# Altium schematic sheet PMIC.SchDoc
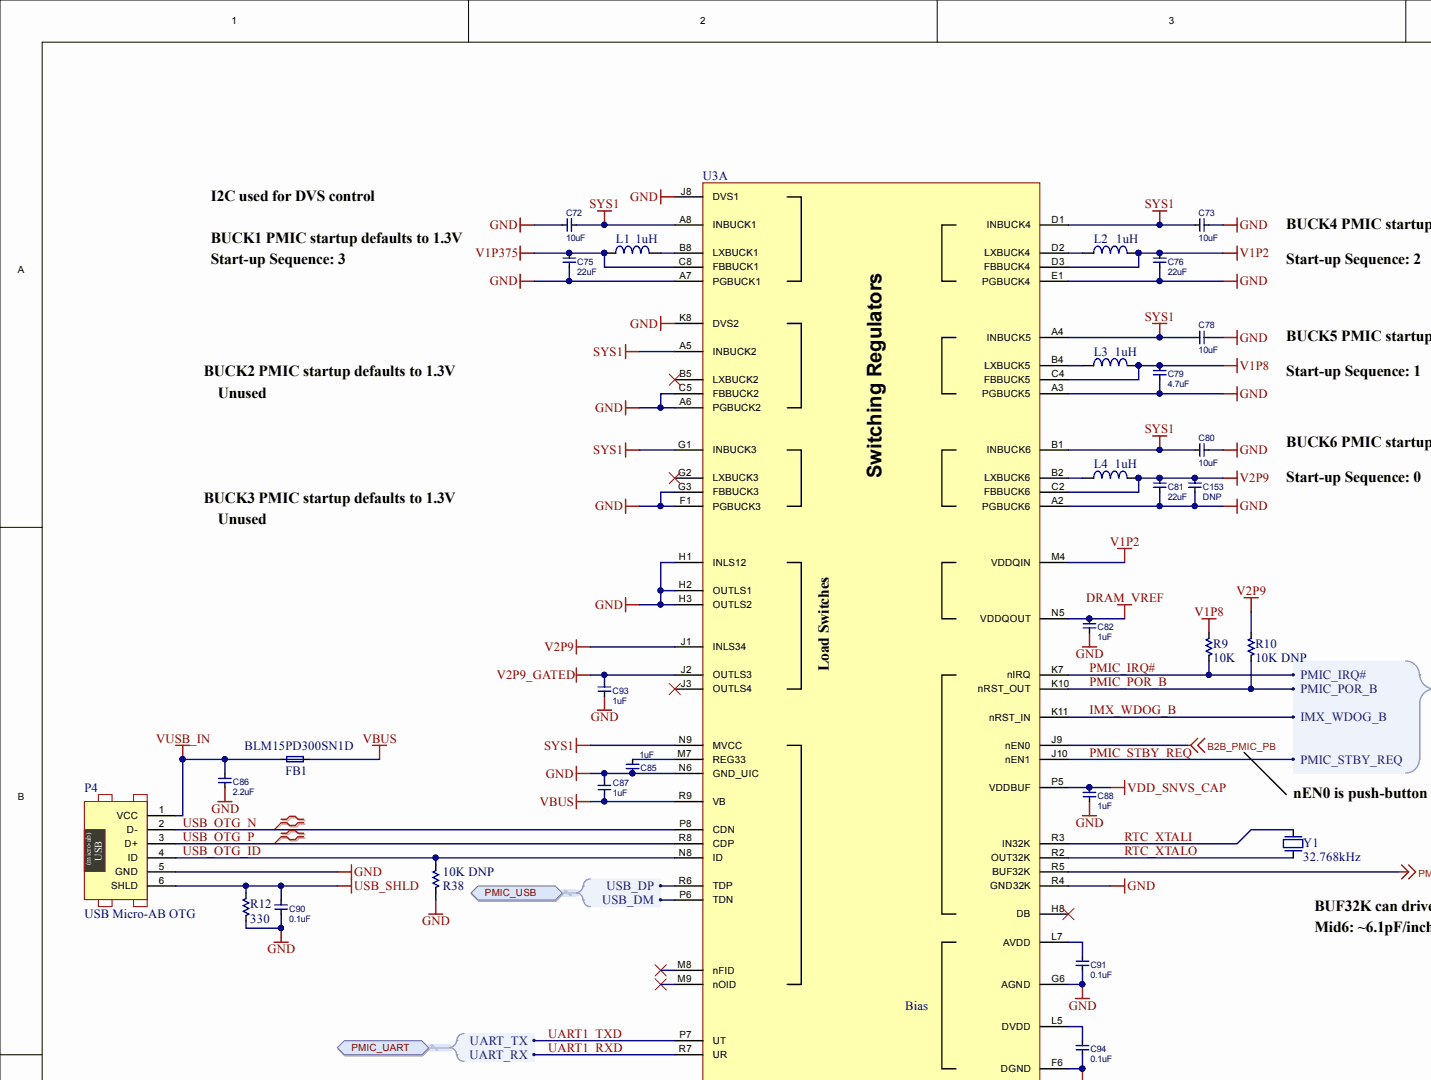
[diagram p0: PMIC.SchDoc, top left]
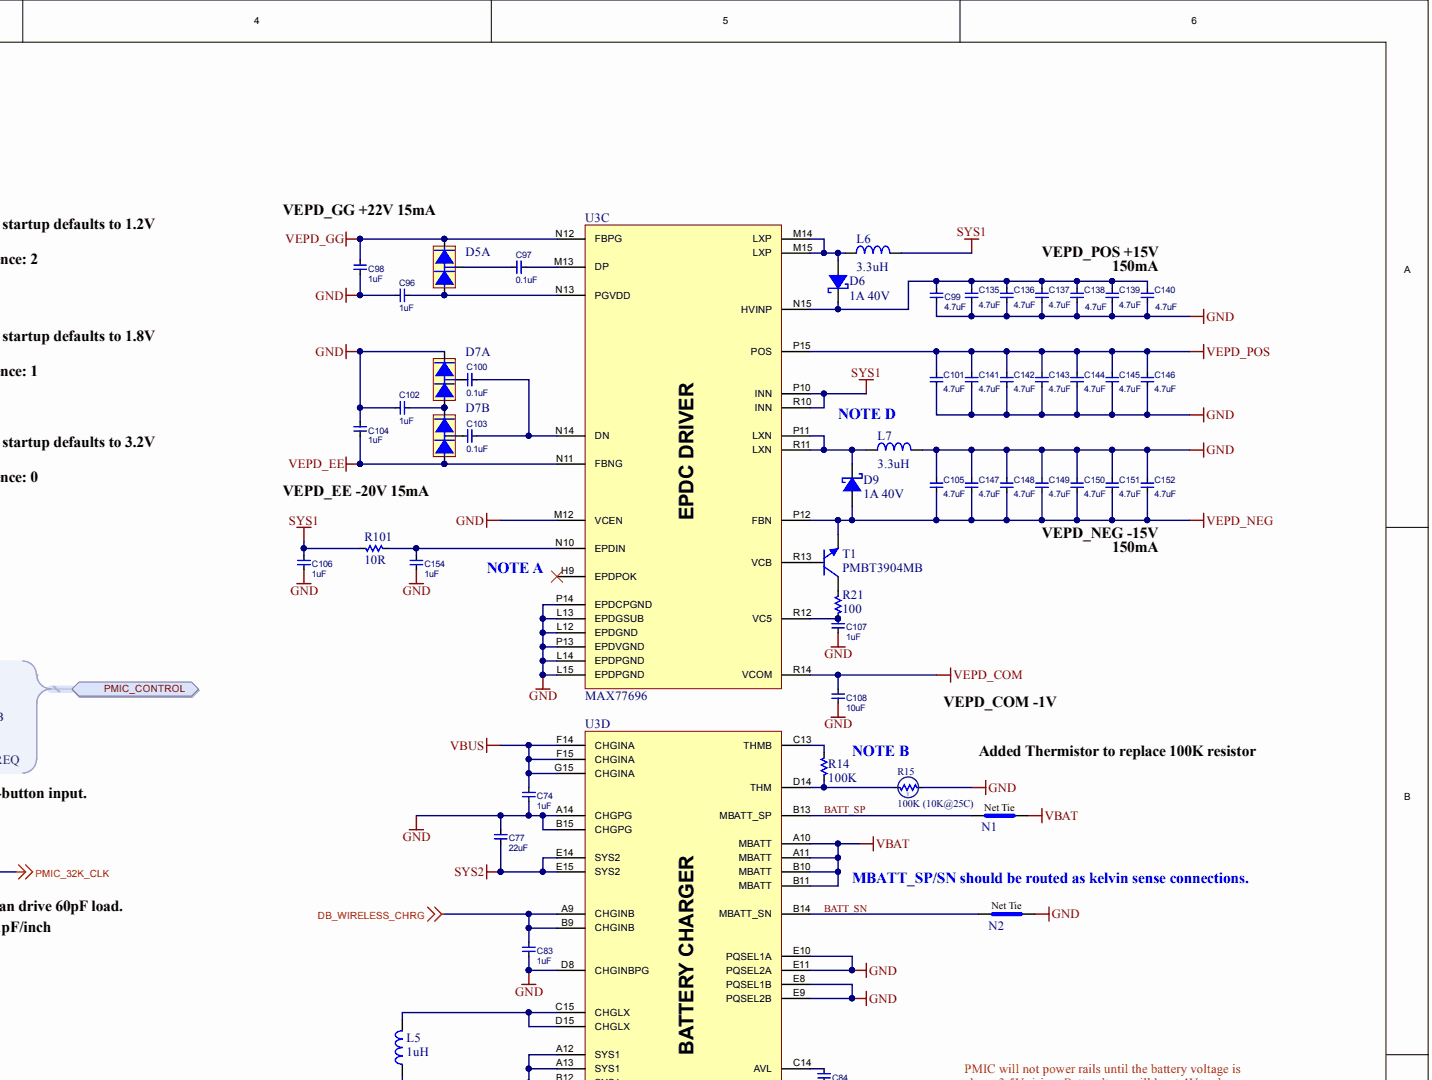
[diagram p1: PMIC.SchDoc, top right]
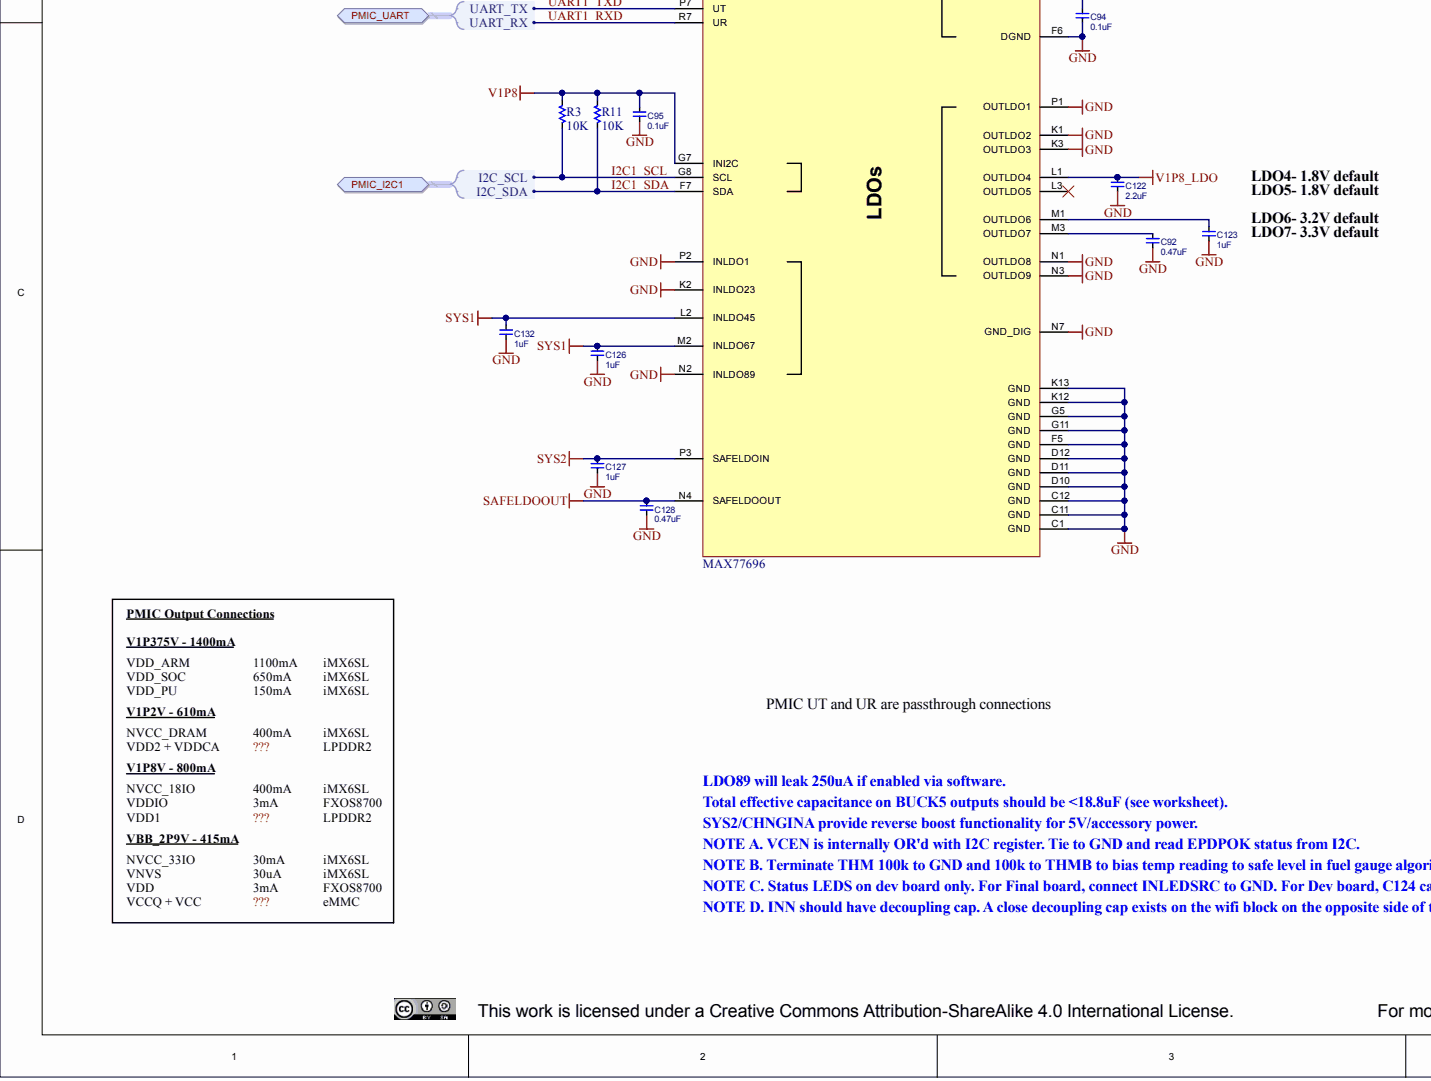
[diagram p2: PMIC.SchDoc, bottom left]
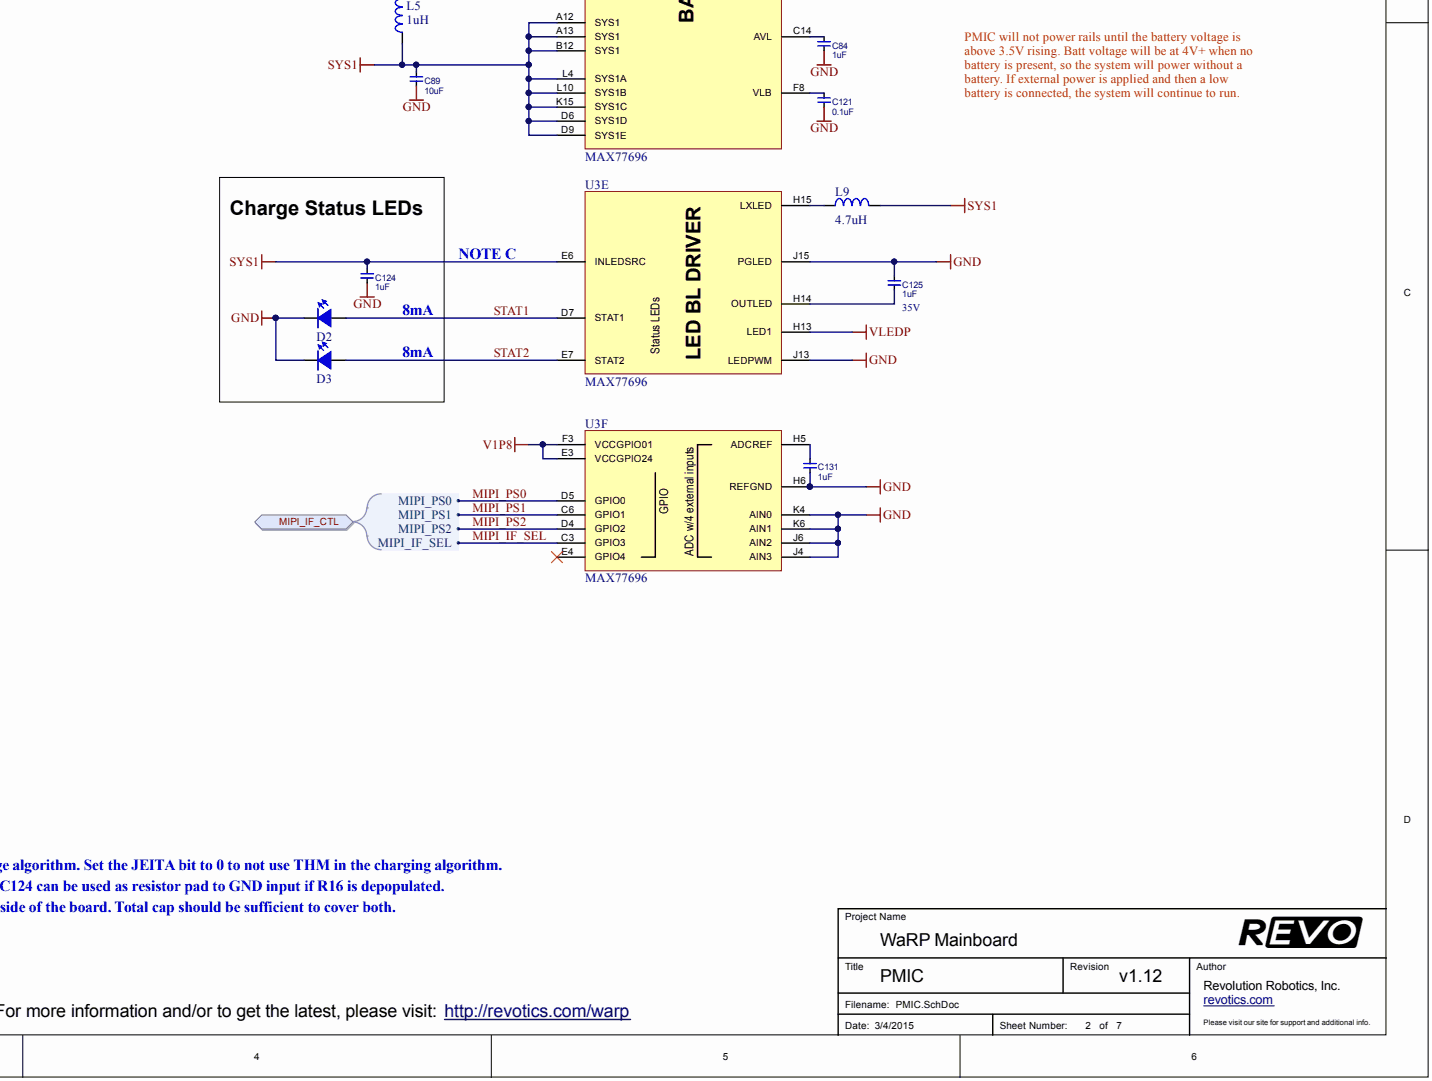
[diagram p3: PMIC.SchDoc, bottom right]

## Parts
C72  value 10uF  [0402 (1005) Cap High Density]  (hidden comment: CapacitorSM)
C73  value 10uF  [0402 (1005) Cap High Density]  (hidden comment: CapacitorSM)
C74  value 1uF  [0201 (0603) Cap High Density]  (hidden comment: CapacitorSM)
C75  value 22uF  [0603 (1608) Cap High Density]  (hidden comment: CapacitorSM)
C76  value 22uF  [0603 (1608) Cap High Density]  (hidden comment: CapacitorSM)
C77  value 22uF  [0603 (1608) Cap High Density]  (hidden comment: CapacitorSM)
C78  value 10uF  [0402 (1005) Cap High Density]  (hidden comment: CapacitorSM)
C79  value 4.7uF  [0402 (1005) Cap High Density]  (hidden comment: CapacitorSM)
C80  value 10uF  [0402 (1005) Cap High Density]  (hidden comment: CapacitorSM)
C81  value 22uF  [0603 (1608) Cap High Density]  (hidden comment: CapacitorSM)
C82  value 1uF  [0201 (0603) Cap High Density]  (hidden comment: CapacitorSM)
C83  value 1uF  [0402 (1005) Cap High Density]  (hidden comment: CapacitorSM)
C84  value 1uF  [0201 (0603) Cap High Density]  (hidden comment: CapacitorSM)
C85  value 1uF  [0201 (0603) Cap High Density]  (hidden comment: CapacitorSM)
C86  value 2.2uF  [0402 (1005) Cap High Density]  (hidden comment: CapacitorSM)
C87  value 1uF  [0201 (0603) Cap High Density]  (hidden comment: CapacitorSM)
C88  value 1uF  [0201 (0603) Cap High Density]  (hidden comment: CapacitorSM)
C89  value 10uF  [0402 (1005) Cap High Density]  (hidden comment: CapacitorSM)
C90  value 0.1uF  [0402 (1005) Cap High Density]  (hidden comment: CapacitorSM)
C91  value 0.1uF  [0201 (0603) Cap High Density]  (hidden comment: CapacitorSM)
C92  value 0.47uF  [0201 (0603) Cap High Density]  (hidden comment: CapacitorSM)
C93  value 1uF  [0201 (0603) Cap High Density]  (hidden comment: CapacitorSM)
C94  value 0.1uF  [0201 (0603) Cap High Density]  (hidden comment: CapacitorSM)
C95  value 0.1uF  [0201 (0603) Cap High Density]  (hidden comment: CapacitorSM)
C96  value 1uF  [0402 (1005) Cap High Density]  (hidden comment: CapacitorSM)
C97  value 0.1uF  [0402 (1005) Cap High Density]  (hidden comment: CapacitorSM)
C98  value 1uF  [0402 (1005) Cap High Density]  (hidden comment: CapacitorSM)
C99  value 4.7uF  [0603 (1608) Cap High Density]  (hidden comment: CapacitorSM)
C100  value 0.1uF  [0402 (1005) Cap High Density]  (hidden comment: CapacitorSM)
C101  value 4.7uF  [0603 (1608) Cap High Density]  (hidden comment: CapacitorSM)
C102  value 1uF  [0402 (1005) Cap High Density]  (hidden comment: CapacitorSM)
C103  value 0.1uF  [0402 (1005) Cap High Density]  (hidden comment: CapacitorSM)
C104  value 1uF  [0402 (1005) Cap High Density]  (hidden comment: CapacitorSM)
C105  value 4.7uF  [0603 (1608) Cap High Density]  (hidden comment: CapacitorSM)
C106  value 1uF  [0201 (0603) Cap High Density]  (hidden comment: CapacitorSM)
C107  value 1uF  [0201 (0603) Cap High Density]  (hidden comment: CapacitorSM)
C108  value 10uF  [0402 (1005) Cap High Density]  (hidden comment: CapacitorSM)
C121  value 0.1uF  [0201 (0603) Cap High Density]  (hidden comment: CapacitorSM)
C122  value 2.2uF  [0402 (1005) Cap High Density]  (hidden comment: CapacitorSM)
C123  value 1uF  [0201 (0603) Cap High Density]  (hidden comment: CapacitorSM)
C124  value 1uF  [0201 (0603) Cap High Density]  (hidden comment: CapacitorSM)
C125  value 1uF  Voltage: 35V  [0402 (1005) Cap High Density]  (hidden comment: CapacitorSM)
C126  value 1uF  [0201 (0603) Cap High Density]  (hidden comment: CapacitorSM)
C127  value 1uF  [0201 (0603) Cap High Density]  (hidden comment: CapacitorSM)
C128  value 0.47uF  [0201 (0603) Cap High Density]  (hidden comment: CapacitorSM)
C131  value 1uF  [0201 (0603) Cap High Density]  (hidden comment: CapacitorSM)
C132  value 1uF  [0201 (0603) Cap High Density]  (hidden comment: CapacitorSM)
C135  value 4.7uF  [0603 (1608) Cap High Density]  (hidden comment: CapacitorSM)
C136  value 4.7uF  [0603 (1608) Cap High Density]  (hidden comment: CapacitorSM)
C137  value 4.7uF  [0603 (1608) Cap High Density]  (hidden comment: CapacitorSM)
C138  value 4.7uF  [0603 (1608) Cap High Density]  (hidden comment: CapacitorSM)
C139  value 4.7uF  [0603 (1608) Cap High Density]  (hidden comment: CapacitorSM)
C140  value 4.7uF  [0603 (1608) Cap High Density]  (hidden comment: CapacitorSM)
C141  value 4.7uF  [0603 (1608) Cap High Density]  (hidden comment: CapacitorSM)
C142  value 4.7uF  [0603 (1608) Cap High Density]  (hidden comment: CapacitorSM)
C143  value 4.7uF  [0603 (1608) Cap High Density]  (hidden comment: CapacitorSM)
C144  value 4.7uF  [0603 (1608) Cap High Density]  (hidden comment: CapacitorSM)
C145  value 4.7uF  [0603 (1608) Cap High Density]  (hidden comment: CapacitorSM)
C146  value 4.7uF  [0603 (1608) Cap High Density]  (hidden comment: CapacitorSM)
C147  value 4.7uF  [0603 (1608) Cap High Density]  (hidden comment: CapacitorSM)
C148  value 4.7uF  [0603 (1608) Cap High Density]  (hidden comment: CapacitorSM)
C149  value 4.7uF  [0603 (1608) Cap High Density]  (hidden comment: CapacitorSM)
C150  value 4.7uF  [0603 (1608) Cap High Density]  (hidden comment: CapacitorSM)
C151  value 4.7uF  [0603 (1608) Cap High Density]  (hidden comment: CapacitorSM)
C152  value 4.7uF  [0603 (1608) Cap High Density]  (hidden comment: CapacitorSM)
C153  value DNP  [0402 (1005) Cap High Density DNP]  (hidden comment: CapacitorSM)
C154  value 1uF  [0201 (0603) Cap High Density]  (hidden comment: CapacitorSM)
D2  LED  [LED 0603 (1608) High Density]  (value not drawn)
D3  LED  [LED 0603 (1608) High Density]  (value not drawn)
D5  BAV99BRV  [SOT-563 - NoMetalMarker]  (value not drawn)  (part A)
D6  value 1A 40V  [0502 Diode]  (hidden comment: Schottky Diode)
D7  BAV99BRV  [SOT-563 - NoMetalMarker]  (value not drawn)  (parts A,B)
D9  value 1A 40V  [0502 Diode]  (hidden comment: Schottky Diode)
FB1  Part No.: BLM15PD300SN1D  [0402 (1005) Cap High Density]  (hidden comment: Ferrite Bead)
L1  value 1uH  [0806 (2016) IND HIGH DENSITY]  (hidden comment: Inductor)
L2  value 1uH  [0806 (2016) IND HIGH DENSITY]  (hidden comment: Inductor)
L3  value 1uH  [0806 (2016) IND HIGH DENSITY]  (hidden comment: Inductor)
L4  value 1uH  [0806 (2016) IND HIGH DENSITY]  (hidden comment: Inductor)
L5  value 1uH  [0806 (2016) IND HIGH DENSITY]  (hidden comment: Inductor)
L6  value 3.3uH  [0808 (2020) IND HIGH DENSITY]  (hidden comment: Inductor)
L7  value 3.3uH  [0808 (2020) IND HIGH DENSITY]  (hidden comment: Inductor)
L9  value 4.7uH  [0808 (2020) IND HIGH DENSITY]  (hidden comment: Inductor)
N1  Net Tie  [NetTieSmall]
N2  Net Tie  [NetTieSmall]
P4  USB Micro-AB OTG  [USB MICRO-AB Mid Board]
R3  value 10K  [0201 (0603) Res High Density]  (hidden comment: Resistor)
R9  value 10K  [0201 (0603) Res High Density]  (hidden comment: Resistor)
R10  value 10K DNP  [0201 (0603) Res High Density DNP]  (hidden comment: Resistor)
R11  value 10K  [0201 (0603) Res High Density]  (hidden comment: Resistor)
R12  value 330  [0402 (1005) Res High Density]  (hidden comment: Resistor)
R14  value 100K  [0201 (0603) Res High Density]  (hidden comment: Resistor)
R15  Thermistor  value 100K (10K@25C)  [0201 (0603) Res High Density]
R21  value 100  [0201 (0603) Res High Density]  (hidden comment: Resistor)
R38  value 10K DNP  [0201 (0603) Res High Density DNP]  (hidden comment: Resistor)  (no BOM)
R101  value 10R  [0201 (0603) Res High Density]  (hidden comment: Resistor)
T1  PMBT3904MB  [SOT883B]
U3  MAX77696  [225 Bump, WLP, 0.4mm pitch]  (parts A,C,D,E,F)
Y1  value 32.768kHz  [ABS05]  (hidden comment: XTAL)

## Ports
MIPI_IF_CTL, MIPI_IF_CTL.MIPI_IF_SEL, MIPI_IF_CTL.MIPI_PS0, MIPI_IF_CTL.MIPI_PS1, MIPI_IF_CTL.MIPI_PS2, PMIC_CONTROL, PMIC_CONTROL.IMX_WDOG_B, PMIC_CONTROL.PMIC_IRQ#, PMIC_CONTROL.PMIC_POR_B, PMIC_CONTROL.PMIC_STBY_REQ, PMIC_I2C1, PMIC_I2C1.I2C_SCL, PMIC_I2C1.I2C_SDA, PMIC_UART, PMIC_UART.UART_RX, PMIC_UART.UART_TX, PMIC_USB, PMIC_USB.USB_DM, PMIC_USB.USB_DP

## Nets
B2B_PMIC_PB: U3.J9(nEN0)
DB_WIRELESS_CHRG: C83.1 U3.A9(CHGINB) U3.B9(CHGINB)
DRAM_VREF: C82.2 U3.N5(VDDQOUT)
GND: C72.1 C73.2 C74.2 C75.2 C76.2 C77.1 C78.2 C79.2 C80.2 C81.2 C82.1 C83.2 C84.2 C85.1 C86.2 C87.2 C88.1 C89.1 C90.1 C91.2 C92.2 C93.1 C94.2 C95.2 C96.1 C98.1 C99.2 C101.1 C102.2 C104.1 C105.2 C106.1 C107.2 C108.2 C121.2 C122.1 C123.2 C124.2 C125.1 C126.2 C127.2 C128.2 C131.2 C132.1 C135.1 C136.1 C137.1 C138.1 C139.1 C140.1 C141.2 C142.2 C143.1 C144.1 C145.1 C146.1 C147.1 C148.1 C149.2 C150.2 C151.2 C152.2 C153.2 C154.1 D2.2 D3.2 D7.5 L7.2 N2.1 P4.5(GND) R12.1 R15.1 R38.1 U3.A2(PGBUCK6) U3.A3(PGBUCK5) U3.A6(PGBUCK2) U3.A7(PGBUCK1) U3.A14(CHGPG) U3.B15(CHGPG) U3.C1(GND) U3.C5(FBBUCK2) U3.C11(GND) U3.C12(GND) U3.D8(CHGINBPG) U3.D10(GND) U3.D11(GND) U3.D12(GND) U3.E1(PGBUCK4) U3.E8(PQSEL1B) U3.E9(PQSEL2B) U3.E10(PQSEL1A) U3.E11(PQSEL2A) U3.F1(PGBUCK3) U3.F5(GND) U3.F6(DGND) U3.G3(FBBUCK3) U3.G5(GND) U3.G6(AGND) U3.G11(GND) U3.H1(INLS12) U3.H2(OUTLS1) U3.H3(OUTLS2) U3.H6(REFGND) U3.J4(AIN3) U3.J6(AIN2) U3.J8(DVS1) U3.J13(LEDPWM) U3.J15(PGLED) U3.K1(OUTLDO2) U3.K2(INLDO23) U3.K3(OUTLDO3) U3.K4(AIN0) U3.K6(AIN1) U3.K8(DVS2) U3.K12(GND) U3.K13(GND) U3.L12(EPDGND) U3.L13(EPDGSUB) U3.L14(EPDPGND) U3.L15(EPDPGND) U3.M12(VCEN) U3.N1(OUTLDO8) U3.N2(INLDO89) U3.N3(OUTLDO9) U3.N6(GND_UIC) U3.N7(GND_DIG) U3.P1(OUTLDO1) U3.P2(INLDO1) U3.P13(EPDVGND) U3.P14(EPDCPGND) U3.R4(GND32K)
PMIC_32K_CLK: U3.R5(BUF32K)
SAFELDOOUT: C128.1 U3.N4(SAFELDOOUT)
SYS1: C72.2 C73.1 C78.1 C80.1 C89.2 C106.2 C124.1 C126.1 C132.2 L5.1 L6.2 L9.2 R101.2 U3.A4(INBUCK5) U3.A5(INBUCK2) U3.A8(INBUCK1) U3.A12(SYS1) U3.A13(SYS1) U3.B1(INBUCK6) U3.B12(SYS1) U3.D1(INBUCK4) U3.D6(SYS1D) U3.D9(SYS1E) U3.E6(INLEDSRC) U3.G1(INBUCK3) U3.K15(SYS1C) U3.L2(INLDO45) U3.L4(SYS1A) U3.L10(SYS1B) U3.M2(INLDO67) U3.N9(MVCC) U3.P10(INN) U3.R10(INN)
SYS2: C77.2 C127.1 U3.E14(SYS2) U3.E15(SYS2) U3.P3(SAFELDOIN)
USB_SHLD: C90.2 P4.6(SHLD) R12.2
V1P2: C76.1 L2.2 U3.D3(FBBUCK4) U3.M4(VDDQIN)
V1P8: C79.1 C95.1 L3.2 R3.2 R9.2 R11.2 U3.C4(FBBUCK5) U3.E3(VCCGPIO24) U3.F3(VCCGPIO01) U3.G7(INI2C)
V1P8_LDO: C122.2 U3.L1(OUTLDO4)
V1P375: C75.1 L1.1 U3.C8(FBBUCK1)
V2P9: C81.1 C153.1 L4.2 R10.2 U3.C2(FBBUCK6) U3.J1(INLS34)
V2P9_GATED: C93.2 U3.J2(OUTLS3)
VBAT: N1.1 U3.A10(MBATT) U3.A11(MBATT) U3.B10(MBATT) U3.B11(MBATT)
VBUS: C74.1 C87.1 FB1.2 U3.F14(CHGINA) U3.F15(CHGINA) U3.G15(CHGINA) U3.R9(VB)
VDD_SNVS_CAP: C88.2 U3.P5(VDDBUF)
VEPD_COM: C108.1 U3.R14(VCOM)
VEPD_EE: C104.2 D7.4 U3.N11(FBNG)
VEPD_GG: C98.2 D5.5 U3.N12(FBPG)
VEPD_NEG: C105.1 C147.2 C148.2 C149.1 C150.1 C151.1 C152.1 D9.1 T1.E U3.P12(FBN)
VEPD_POS: C101.2 C141.1 C142.1 C143.2 C144.2 C145.2 C146.2 U3.P15(POS)
VLEDP: U3.H13(LED1)
VUSB_IN: C86.1 FB1.1 P4.1(VCC)
BATT_SN: N2.2 U3.B14(MBATT_SN)
BATT_SP: N1.2 U3.B13(MBATT_SP)
I2C1_SCL: R3.1 U3.G8(SCL) ; port PMIC_I2C1
I2C1_SDA: R11.1 U3.F7(SDA) ; port PMIC_I2C1
IMX_WDOG_B: U3.K11(nRST_IN) ; port PMIC_CONTROL
MIPI_IF_SEL: U3.C3(GPIO3) ; port MIPI_IF_CTL
MIPI_PS0: U3.D5(GPIO0) ; port MIPI_IF_CTL
MIPI_PS1: U3.C6(GPIO1) ; port MIPI_IF_CTL
MIPI_PS2: U3.D4(GPIO2) ; port MIPI_IF_CTL
PMIC_IRQ#: R9.1 U3.K7(nIRQ) ; port PMIC_CONTROL
PMIC_POR_B: R10.1 U3.K10(nRST_OUT) ; port PMIC_CONTROL
PMIC_STBY_REQ: U3.J10(nEN1) ; port PMIC_CONTROL
RTC_XTALI: U3.R3(IN32K) Y1.2
RTC_XTALO: U3.R2(OUT32K) Y1.1
STAT1: D2.1 U3.D7(STAT1)
STAT2: D3.1 U3.E7(STAT2)
UART1_RXD: U3.R7(UR) ; port PMIC_UART
UART1_TXD: U3.P7(UT) ; port PMIC_UART
USB_OTG_ID: P4.4(ID) R38.2 U3.N8(ID)
USB_OTG_N: P4.2(D-) U3.P8(CDN)
USB_OTG_P: P4.3(D+) U3.R8(CDP)
NetC84_1: C84.1 U3.C14(AVL)
NetC85_2: C85.2 U3.M7(REG33)
NetC91_1: C91.1 U3.L7(AVDD)
NetC92_1: C92.1 U3.M3(OUTLDO7)
NetC94_1: C94.1 U3.L5(DVDD)
NetC96_2: C96.2 D5.1 U3.N13(PGVDD)
NetC97_1: C97.1 D5.6
NetC97_2: C97.2 U3.M13(DP)
NetC99_1: C99.1 C135.2 C136.2 C137.2 C138.2 C139.2 C140.2 D6.2 U3.N15(HVINP)
NetC100_1: C100.1 D7.6
NetC100_2: C100.2 C103.2 U3.N14(DN)
NetC102_1: C102.1 D7.1 D7.2
NetC103_1: C103.1 D7.3
NetC107_1: C107.1 R21.1 U3.R12(VC5)
NetC121_1: C121.1 U3.F8(VLB)
NetC123_1: C123.1 U3.M1(OUTLDO6)
NetC125_2: C125.2 U3.H14(OUTLED)
NetC131_1: C131.1 U3.H5(ADCREF)
NetC154_2: C154.2 R101.1 U3.N10(EPDIN)
NetD6_1: D6.1 L6.1 U3.M14(LXP) U3.M15(LXP)
NetD9_2: D9.2 L7.1 U3.P11(LXN) U3.R11(LXN)
NetL1_2: L1.2 U3.B8(LXBUCK1)
NetL2_1: L2.1 U3.D2(LXBUCK4)
NetL3_1: L3.1 U3.B4(LXBUCK5)
NetL4_1: L4.1 U3.B2(LXBUCK6)
NetL5_2: L5.2 U3.C15(CHGLX) U3.D15(CHGLX)
NetL9_1: L9.1 U3.H15(LXLED)
NetR14_1: R14.1 R15.2 U3.D14(THM)
NetR14_2: R14.2 U3.C13(THMB)
NetR21_2: R21.2 T1.C
NetT1_B: T1.B U3.R13(VCB)
NetU3_P6: U3.P6(TDN) ; port PMIC_USB
NetU3_R6: U3.R6(TDP) ; port PMIC_USB

Not connected: U3 B5(LXBUCK2) E4(GPIO4) G2(LXBUCK3) H8(DB) H9(EPDPOK) J3(OUTLS4) L3(OUTLDO5) M8(nFID) M9(nOID)

## Library data of the parts
C72 C73 C78 C80 C89 C108 = CapacitorSM: Capacitor; Manufacturer: Samsung; Part No.: CL05A106MQ5NUNC; Vendor: Digikey; Voltage: 6.3; Component Kind: Standard; Library Name: Passive.SchLib
C74 C82 C84 C85 C87 C88 C93 C106 C107 C123 C124 C126 C127 C131 C132 C154 = CapacitorSM: Capacitor; Manufacturer: TDK; Part No.: C0603X5R0J105M030BC; Vendor: Digikey; Voltage: 6.3; Component Kind: Standard; Library Name: Passive.SchLib
C75-C77 C81 = CapacitorSM: Capacitor; Manufacturer: Murata; Part No.: GRM188C80G226MEA0D; Vendor: Mouser; Component Kind: Standard; Library Name: Passive.SchLib
C79 = CapacitorSM: Capacitor; Manufacturer: TDK; Part No.: C1005X5R0J475M050BC; Vendor: Digikey; Voltage: 6.3; Component Kind: Standard; Library Name: Passive.SchLib
C83 C96 C98 C102 C104 = CapacitorSM: Capacitor; Manufacturer: TDK; Part No.: C1005X5R1V105M050BC; Vendor: Digikey; Voltage: 35V; Component Kind: Standard; Library Name: Passive.SchLib
C86 C122 = CapacitorSM: Capacitor; Manufacturer: Taiyo Yuden; Part No.: LMK105BJ225MV-F; Vendor: Digikey; Component Kind: Standard; Library Name: Passive.SchLib
  C86: Supplier 1: Digi-Key; Supplier Part Number 1: 587-3153-1-ND
C90 C97 C100 C103 = CapacitorSM: Capacitor; Manufacturer: TDK; Part No.: C1005X5R1H104K050BB; Vendor: Digikey; Voltage: 50V; Component Kind: Standard; Library Name: Passive.SchLib
C91 C94 C95 C121 = CapacitorSM: Capacitor; Manufacturer: Murata; Part No.: GRM033R60J104ME19D; Vendor: Digikey; Voltage: 6.3; Component Kind: Standard; Library Name: Passive.SchLib
C92 C128 = CapacitorSM: Capacitor; Manufacturer: TDK; Part No.: C0603X5R0J474M030BC; Vendor: Digikey; Voltage: 6.3; Component Kind: Standard; Library Name: Passive.SchLib
C99 C101 C105 C135-C152 = CapacitorSM: Capacitor; Manufacturer: TDK; Part No.: C1608X5R1E475K080AC; Vendor: Digikey; Voltage: 25V; Component Kind: Standard; Library Name: Passive.SchLib
C125 = CapacitorSM: Capacitor; Manufacturer: TDK; Part No.: C1005X5R1V105M050BC; Vendor: Digikey; Component Kind: Standard; Library Name: Passive.SchLib
C153 = CapacitorSM: Capacitor; Vendor: Digikey; Component Kind: Standard; Library Name: Passive.SchLib
D2 = LED: Manufacturer: Lite-On; Part No.: LTST-C190KGKT; Color: Green; Vendor: Mouser; Component Kind: Standard; Description: LED; Library Name: Diode.SchLib
D3 = LED: Manufacturer: Lite-On; Part No.: LTST-C190YKT; Color: Yellow; Vendor: Mouser; Component Kind: Standard; Description: LED; Library Name: Diode.SchLib
D5 D7 = BAV99BRV: Dual Series Connected Diodes; Manufacturer: Diodes Inc; Vendor: Digikey; Component Kind: Standard; ComponentLink1Description: Datasheet; Library Name: Diode.SchLib; Part No.: BAV99BRV; ComponentLink1URL: R:\Hardware\Part Datasheets\Diode\BAV99BRV.pdf
D6 D9 = Schottky Diode: Manufacturer: ON Semi; Part No.: NSR10F40NXT5G; Vendor: Mouser; Component Kind: Standard; Description: Schottky Diode; Library Name: Diode.SchLib
FB1 = Ferrite Bead: Manufacturer: Murata; Note: 30 Ohms Impedance 0.035ohm Resistance 2.2A Current Limit; Vendor: Digikey; Component Kind: Standard; Description: Ferrite Bead; Library Name: Passive.SchLib
L1-L5 = Inductor: Manufacturer: Bourns; Part No.: SRN2010-1R0M; Vendor: Digikey; Component Kind: Standard; ComponentLink1Description: Datasheet; Description: Inductor; Library Name: Passive.SchLib; ComponentLink1URL: R:\Hardware\Part Datasheets\Inductor\bourns_SRN2010-347420.pdf
L6 L7 = Inductor: Manufacturer: Taiyo Yuden; Part No.: NRS2012T3R3MGJ; Vendor: Digikey; Component Kind: Standard; ComponentLink1Description: Datasheet; ComponentLink1URL: http://www.yuden.co.jp/eu/product/category/inductor/NRS2012T3R3MGJ.html; Description: Inductor; Library Name: Passive.SchLib
L9 = Inductor: Manufacturer: Taiyo Yuden; Part No.: NRS2012T4R7MGJ; Vendor: Digikey; Component Kind: Standard; ComponentLink1Description: Datasheet; ComponentLink1URL: http://www.yuden.co.jp/eu/product/category/inductor/NRS2012T4R7MGJ.html; Description: Inductor; Library Name: Passive.SchLib
P4 = USB Micro-AB OTG: USB Micro-AB; Manufacturer: JST Sales America Inc; Part No.: UB-MC5ABR3-M04-4S-TB-1 NMP; Component Kind: Standard; ComponentLink1Description: http://media.digikey.com/pdf/Data%20Sheets/JST%20PDFs/UB-MC5ABR3-M04-4S.pdf; ComponentLink1URL: http://media.digikey.com/pdf/Data%20Sheets/JST%20PDFs/UB-MC5ABR3-M04-4S.pdf; Library Name: USB Connectors.SchLib; Supplier 1: Digi-Key; Supplier Part Number 1: 455-2568-1-ND
R3 R9-R11 R38 = Resistor: Manufacturer: Vishay / Dale; Part No.: CRCW020110K0FNED; Part No. Ref: CRCW[PACKAGE][VALUE]; Vendor: Digikey; Description: Resistor; Library Name: Passive.SchLib; Simulation: RES
  R3 R9-R11: Component Kind: Standard
R12 = Resistor: Manufacturer: Vishay / Dale; Part No.: CRCW0402330RFKED; Part No. Ref: CRCW[PACKAGE][VALUE]; Vendor: Digikey; Component Kind: Standard; Description: Resistor; Library Name: Passive.SchLib; Simulation: RES
R14 = Resistor: Manufacturer: Vishay / Dale; Part No.: CRCW0201100KFNED; Part No. Ref: CRCW[PACKAGE][VALUE]; Vendor: Digikey; Component Kind: Standard; Description: Resistor; Library Name: Passive.SchLib; Simulation: RES
R15 = Thermistor: Tempurature based resistor; Manufacturer: Murata Electronics; Part No.: NCP03XH103J05RL; Component Kind: Standard; ComponentLink1Description: Datasheet; ComponentLink1URL: http://www.murata.com/products/catalog/pdf/r44e.pdf; Library Name: Passive.SchLib
R21 = Resistor: Manufacturer: Vishay / Dale; Part No.: CRCW0201100RFNED; Part No. Ref: CRCW[PACKAGE][VALUE]; Vendor: Digikey; Component Kind: Standard; Description: Resistor; Library Name: Passive.SchLib; Simulation: RES
R101 = Resistor: Manufacturer: Vishay / Dale; Part No.: CRCW020110xxxxxxxxx; Part No. Ref: CRCW[PACKAGE][VALUE]; Vendor: Digikey; Component Kind: Standard; Description: Resistor; Library Name: Passive.SchLib; Simulation: RES
T1 = NPN: NPN Small Signal Trasmistor SOT883B; Manufacturer: NXP; Vendor: Newark; Component Kind: Standard; ComponentLink1Description: Datasheet; Library Name: Mosfet.SchLib; Part No.: PMBT3904MB; ComponentLink1URL: R:\Hardware\Part Datasheets\BJT\PMBT3904MB.pdf
U3 = MAX77696: PMIC for iMX6SL e-readers; Manufacturer: Maxim; Component Kind: Standard; ComponentLink1Description: Datasheet; Library Name: MAX77696.SchLib; Part No.: MAX77696; Price Est.: ?; Vendor: ?; ComponentLink1URL: R:\Hardware\Part Datasheets\DC-DC\MAX776XX Datasheet Rev 3 2013-8-8.pdf
Y1 = XTAL: Manufacturer: Abracon; Part No.: ABS05-102-32.768kHz-T; Vendor: Mouser; Component Kind: Standard; ComponentLink1Description: Datasheet; Description: XTAL; Library Name: XTAL.SchLib; ComponentLink1URL: R:\Hardware\Part Datasheets\Oscillator\ABS05.pdf
N1 N2 = Net Tie: Component Kind: Net Tie; Library Name: NetTie.SchLib
